# Altium schematic sheet Output.SchDoc
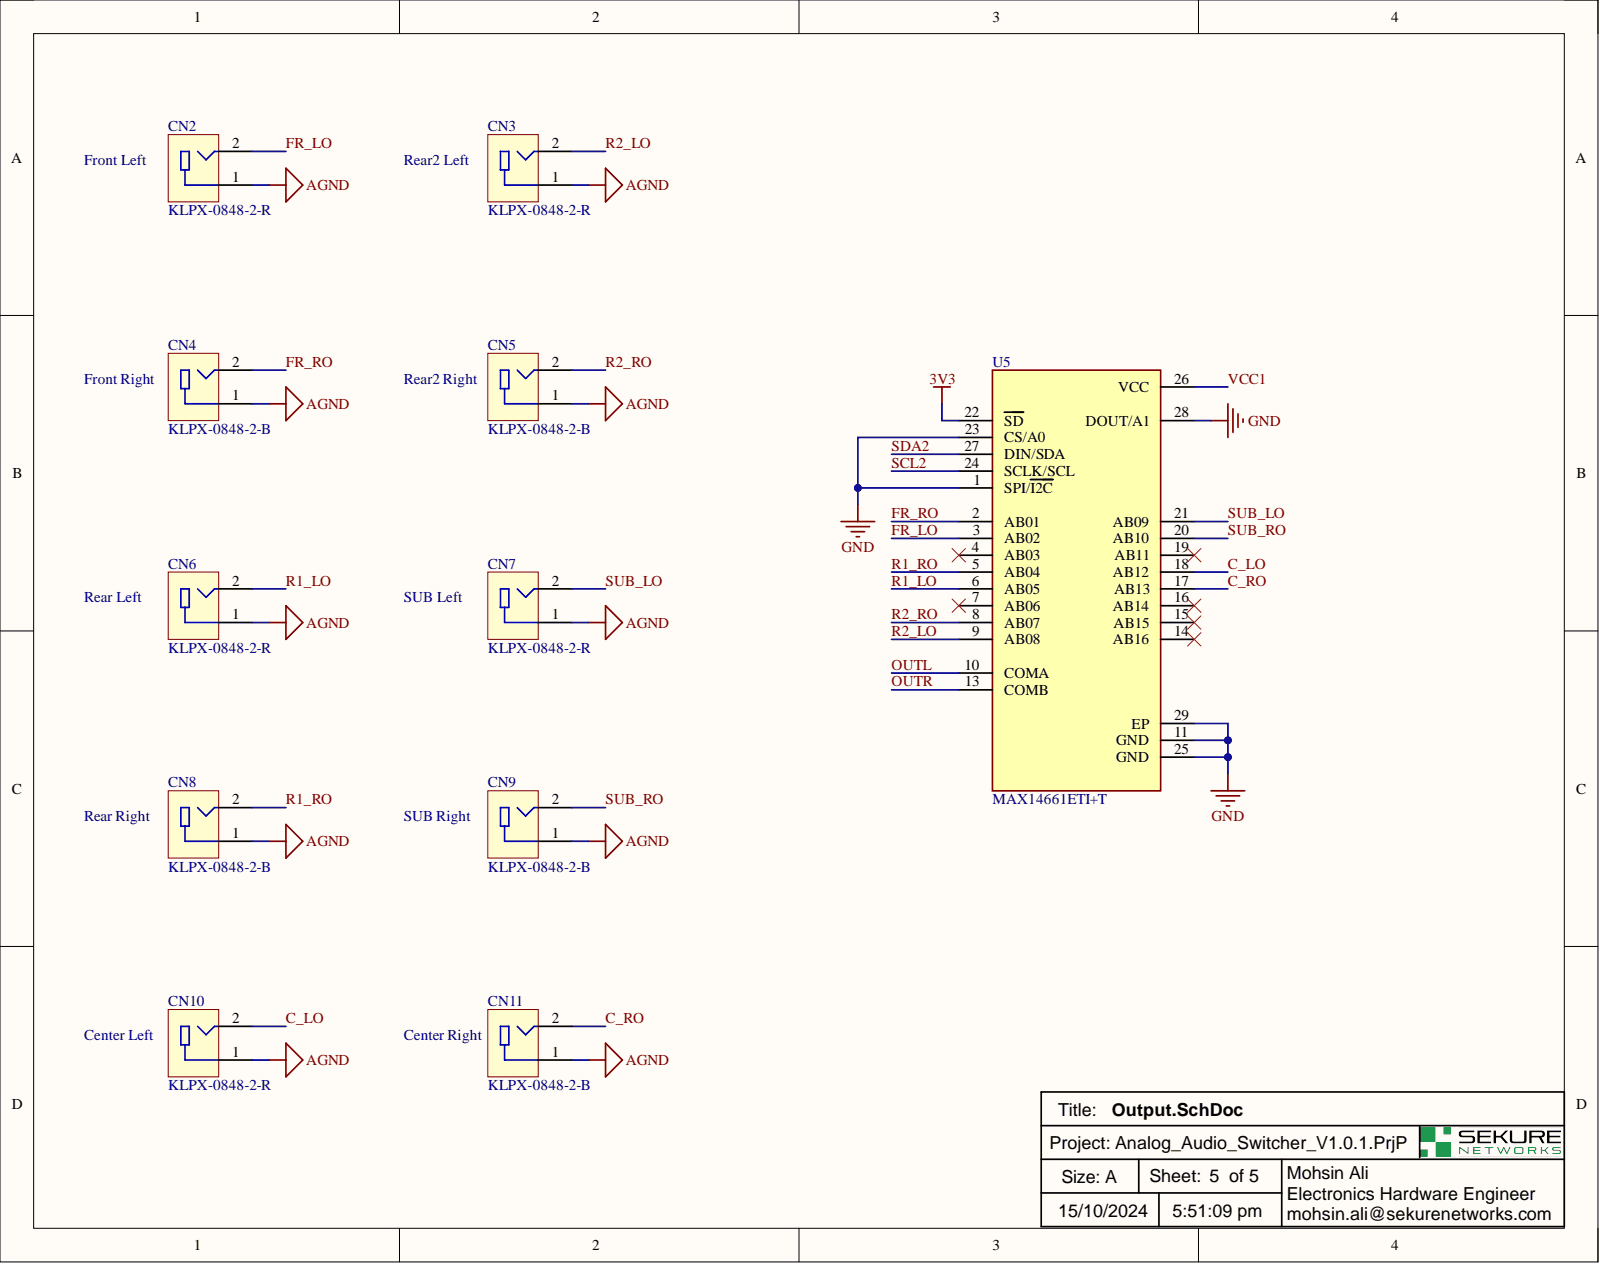
[diagram p0: Output.SchDoc]

## Parts
CN2  value KLPX-0848-2-R  [KLPX-0848-2-R]  (hidden comment: Connector)
CN3  value KLPX-0848-2-R  [KLPX-0848-2-R]  (hidden comment: Connector)
CN4  value KLPX-0848-2-B  [KLPX-0848-2-B]  (hidden comment: Connector)
CN5  value KLPX-0848-2-B  [KLPX-0848-2-B]  (hidden comment: Connector)
CN6  value KLPX-0848-2-R  [KLPX-0848-2-R]  (hidden comment: Connector)
CN7  value KLPX-0848-2-R  [KLPX-0848-2-R]  (hidden comment: Connector)
CN8  value KLPX-0848-2-B  [KLPX-0848-2-B]  (hidden comment: Connector)
CN9  value KLPX-0848-2-B  [KLPX-0848-2-B]  (hidden comment: Connector)
CN10  value KLPX-0848-2-R  [KLPX-0848-2-R]  (hidden comment: Connector)
CN11  value KLPX-0848-2-B  [KLPX-0848-2-B]  (hidden comment: Connector)
U5  value MAX14661ETI+T  [QFN28]  (hidden comment: IC)

## Nets
3V3: U5.22(SD)
AGND: CN2.1 CN3.1 CN4.1 CN5.1 CN6.1 CN7.1 CN8.1 CN9.1 CN10.1 CN11.1
GND: U5.1(SPI/I2C) U5.11(GND) U5.23(CS/A0) U5.25(GND) U5.28(DOUT/A1) U5.29(EP)
C_LO: CN10.2 U5.18(AB12)
C_RO: CN11.2 U5.17(AB13)
FR_LO: CN2.2 U5.3(AB02)
FR_RO: CN4.2 U5.2(AB01)
OUTL: U5.10(COMA)
OUTR: U5.13(COMB)
R1_LO: CN6.2 U5.6(AB05)
R1_RO: CN8.2 U5.5(AB04)
R2_LO: CN3.2 U5.9(AB08)
R2_RO: CN5.2 U5.8(AB07)
SCL2: U5.24(SCLK/SCL)
SDA2: U5.27(DIN/SDA)
SUB_LO: CN7.2 U5.21(AB09)
SUB_RO: CN9.2 U5.20(AB10)
VCC1: U5.26(VCC)

Not connected: U5 4(AB03) 7(AB06) 14(AB16) 15(AB15) 16(AB14) 19(AB11)

## Library data of the parts
CN2 CN3 CN6 CN7 CN10 = KLPX-0848-2-R: RCA Phono Connectors PCB VERTICAL JACK RED; Manufacturer: Kycon; Category: Connectors; Component Kind: Standard; ComponentLink1Description: http://www.mouser.com/ds/2/222/KLPX-334830.pdf?src-supplier=Mouser; ComponentLink1URL: http://www.mouser.com/ds/2/222/KLPX-334830.pdf?src-supplier=Mouser; ComponentLink2Description: http://domino2.kycon.com/catalog_PDF/Standard%20Catalog%20page/RCA%20Phono%20Jacks/SKLPX.pdf?src-supplier=Avnet; ComponentLink2URL: http://domino2.kycon.com/catalog_PDF/Standard%20Catalog%20page/RCA%20Phono%20Jacks/SKLPX.pdf?src-supplier=Avnet; ComponentLink3Description: http://www.kycon.com/2013Catalogpage/RCA/KLPX.pdf?src-supplier=Digikey; ComponentLink3URL: http://www.kycon.com/2013Catalogpage/RCA/KLPX.pdf?src-supplier=Digikey; ComponentLink4Description: https://datasheet.octopart.com/KLPX-0848-2-R-Kycon-datasheet-116534117.pdf?src-supplier=Toby+Electronics; ComponentLink4URL: https://datasheet.octopart.com/KLPX-0848-2-R-Kycon-datasheet-116534117.pdf?src-supplier=Toby+Electronics; DigiKey: 2092-KLPX-0848-2-R-ND; Library Name: Connectors.SchLib; P/N: KLPX-0848-2-R; Pricing: 1=1.16, 10=0.885, 25=0.7956, 50=0.7348, 100=0.6795, 250=0.61388, 500=0.5695, 1000=0.52924, 2500=0.48174 (USD); RoHS: Yes; Stock: 615; Supplier: Digikey
CN4 CN5 CN8 CN9 CN11 = KLPX-0848-2-B: RCA Phono Connectors PCB VERTICAL JACK BLACK; Manufacturer: Kycon; Category: Connectors; Component Kind: Standard; ComponentLink1Description: http://www.mouser.com/ds/2/222/KLPX-334830.pdf?src-supplier=Mouser; ComponentLink1URL: http://www.mouser.com/ds/2/222/KLPX-334830.pdf?src-supplier=Mouser; ComponentLink2Description: http://domino2.kycon.com/catalog_PDF/Standard%20Catalog%20page/RCA%20Phono%20Jacks/SKLPX.pdf?src-supplier=Avnet; ComponentLink2URL: http://domino2.kycon.com/catalog_PDF/Standard%20Catalog%20page/RCA%20Phono%20Jacks/SKLPX.pdf?src-supplier=Avnet; ComponentLink3Description: http://www.kycon.com/2013Catalogpage/RCA/KLPX.pdf?src-supplier=Digikey; ComponentLink3URL: http://www.kycon.com/2013Catalogpage/RCA/KLPX.pdf?src-supplier=Digikey; ComponentLink4Description: https://datasheet.octopart.com/KLPX-0848-2-B-Kycon-datasheet-116534117.pdf?src-supplier=Toby+Electronics; ComponentLink4URL: https://datasheet.octopart.com/KLPX-0848-2-B-Kycon-datasheet-116534117.pdf?src-supplier=Toby+Electronics; DigiKey: 2092-KLPX-0848-2-B-ND; Library Name: Connectors.IntLib; P/N: KLPX-0848-2-B; Pricing: 1=0.98, 10=0.826, 25=0.7928, 50=0.7758, 100=0.7421, 250=0.67468, 500=0.60722, 1000=0.53974, 2500=0.48914 (USD); RoHS: Yes; Stock: 3475; Supplier: Digikey
U5 = MAX14661ETI+T: Analog Multiplexer Single 16: 2 28-Pin TQFN T/R; Manufacturer: Maxim; -3db Bandwidth: 60MHz; Number of Circuits: 1; Category: Decoders and Multiplexers; Component Kind: Standard; ComponentLink1Description: http://www.mouser.com/ds/2/256/MAX14661-263830.pdf?src-supplier=Mouser; ComponentLink1URL: http://www.mouser.com/ds/2/256/MAX14661-263830.pdf?src-supplier=Mouser; ComponentLink2Description: https://datasheets.maximintegrated.com/en/ds/MAX14661.pdf?src-supplier=Digikey; ComponentLink2URL: https://datasheets.maximintegrated.com/en/ds/MAX14661.pdf?src-supplier=Digikey; ComponentLink3Description: http://datasheets.maximintegrated.com/en/ds/MAX14661.pdf?src-supplier=Digikey; ComponentLink3URL: http://datasheets.maximintegrated.com/en/ds/MAX14661.pdf?src-supplier=Digikey; DigiKey: MAX14661ETI+TCT-ND; Library Name: IC's.IntLib; Max Operating Temperature: 85°C; Min Operating Temperature: -40°C; P/N: MAX14661ETI+T; Package Quantity: 2500; Packaging: Tape and Reel; Pins: 28; Pricing: 1=12.18, 10=8.575, 25=7.6476, 100=6.6109, 250=6.10876, 500=5.80302, 1000=5.625 (USD); RoHS: Yes; RoHS Compliant: Yes; Series: -; Stock: 15711; Supplier: Digikey
